# Altium schematic sheet CAN_RPi_CAN.SchDoc
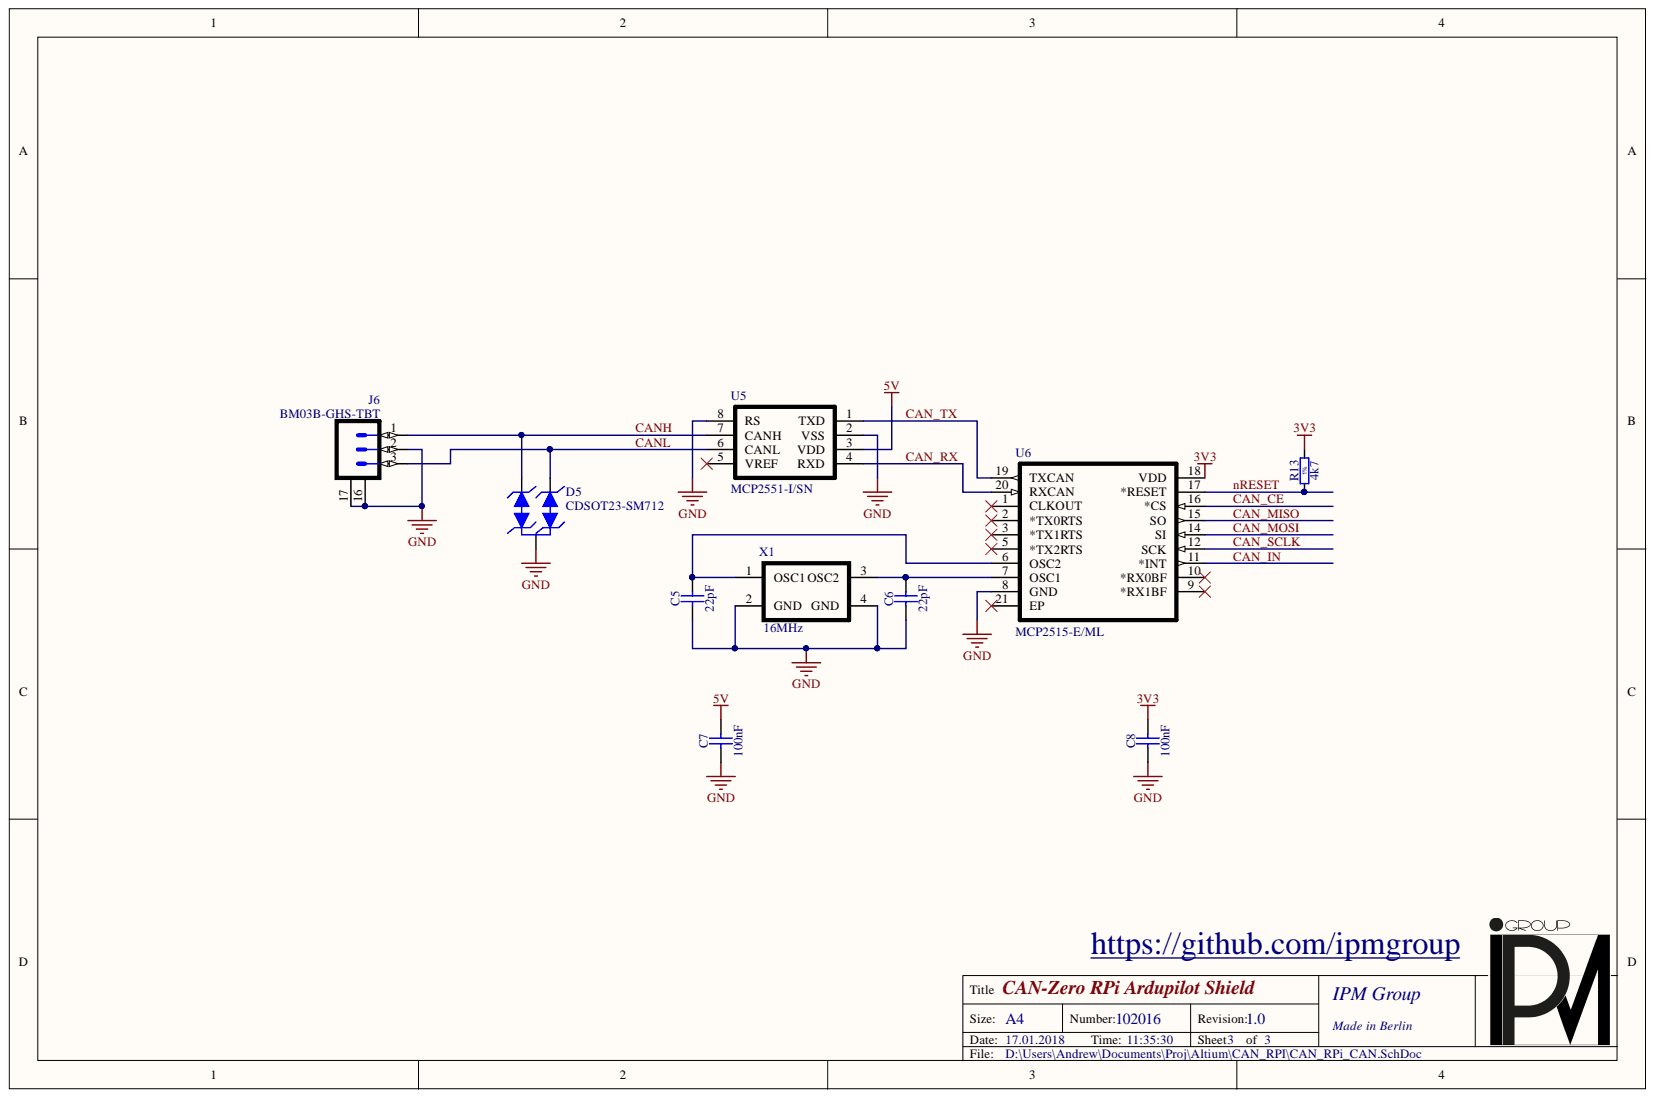
[diagram p0: CAN_RPi_CAN.SchDoc]

## Parts
C5  22pF  [CAPC1005X55N]
C6  22pF  [CAPC1005X55N]
C7  100nF  [CAPC1608X87N]
C8  100nF  [CAPC1005X55N]
D5  CDSOT23-SM712  [SOT23_N]
J6  BM03B-GHS-TBT  [JST_BM03B]
R13  4k7  [RESC1005X40N]
U5  MCP2551-I/SN  [SOIC127P600X175-8N]
U6  MCP2515-E/ML  [QFN50P400X400X100_HS-21N]
X1  value 16MHz  [CRYSTAL-3.2-2.5]  (hidden comment: X1E0000210013 TSX-3225 16 MHZ 9.0PF)

## Nets
3V3: C8.2 R13.2 U6.18(VDD)
5V: C7.2 U5.3(VDD)
GND: C5.1 C6.1 C7.1 C8.1 D5.3 J6.2 J6.16 J6.17 U5.2(VSS) U5.8(RS) U6.8(GND) X1.2(GND) X1.4(GND)
CAN_CE: U6.16(*CS)
CAN_IN: U6.11(*INT)
CAN_MISO: U6.15(SO)
CAN_MOSI: U6.14(SI)
CAN_RX: U5.4(RXD) U6.20(RXCAN)
CAN_SCLK: U6.12(SCK)
CAN_TX: U5.1(TXD) U6.19(TXCAN)
CANH: D5.2 J6.1 U5.7(CANH)
CANL: D5.1 J6.3 U5.6(CANL)
nRESET: R13.1 U6.17(*RESET)
NetC5_2: C5.2 U6.6(OSC2) X1.1(OSC1)
NetC6_2: C6.2 U6.7(OSC1) X1.3(OSC2)

Not connected: U5 5(VREF); U6 1(CLKOUT) 2(*TX0RTS) 3(*TX1RTS) 5(*TX2RTS) 9(*RX1BF) 10(*RX0BF) 21(EP)

## Library data of the parts
C5 C6 = CC0402_22PF_50V_5%_NP0: Non-polarised capacitor; Manufacturer: GENERIC; Manufacturer1 Part Number: 223886915229; Part Description: SMD Multilayer Chip Ceramic Capacitor; Database Table Name: Capacitors SMD; TC: NP0; Tolerance: ±5%; Voltage: 50V; Case: 0402; Component Kind: Standard; Component Type: Standard; Library Name: Capacitors.DbLib; Manufacturer1 ComponentHeight: 0.55mm; Manufacturer1 Example: PHYCOMP; Manufacturer Part Number: CC0402_22PF_50V_5%_NP0; Mounted: Yes; Part Number: CC0402_22PF_50V_5%_NP0; Pin Count: 2; SMD: Yes; Supplier 1: Farnell; Supplier 2: RSComponents; Supplier 3: Digi-Key; Value: 22pF; Author: CERN DEM JLC
C7 = CC0603_100NF_50V_10%_X7R: Non-polarised capacitor; Manufacturer: GENERIC; Manufacturer1 Part Number: 223858615649; Part Description: SMD Multilayer Chip Ceramic Capacitor; Database Table Name: Capacitors SMD; TC: X7R; Tolerance: ±10%; Voltage: 50V; Case: 0603; Component Kind: Standard; Component Type: Standard; Library Name: Capacitors.DbLib; Manufacturer1 ComponentHeight: 0.87mm; Manufacturer1 Example: PHYCOMP; Manufacturer Part Number: CC0603_100NF_50V_10%_X7R; Mounted: Yes; Part Number: CC0603_100NF_50V_10%_X7R; Pin Count: 2; SMD: Yes; Supplier 1: Farnell; Supplier 2: RSComponents; Supplier 3: Digi-Key; Value: 100nF; Author: CERN DEM JLC
C8 = CC0402_100NF_16V_10%_X7R: Non-polarised capacitor; Manufacturer: GENERIC; Manufacturer1 Part Number: GRM155R71C104KA88D; Part Description: SMD Multilayer Chip Ceramic Capacitor; Database Table Name: Capacitors SMD; TC: X7R; Tolerance: ±10%; Voltage: 16V; Case: 0402; Component Kind: Standard; Component Type: Standard; Library Name: Capacitors.DbLib; Manufacturer1 ComponentHeight: 0.55mm; Manufacturer1 Example: MURATA; Manufacturer Part Number: CC0402_100NF_16V_10%_X7R; Mounted: Yes; Part Number: CC0402_100NF_16V_10%_X7R; Pin Count: 2; SMD: Yes; Supplier 1: Farnell; Supplier 2: RSComponents; Supplier 3: Digi-Key; Value: 100nF; Author: CERN DEM JLC
D5 = D Zener2_1: Zener Diode; Manufacturer: BOURNS; Part Description: TVS Diode 7 V or 12 V; Case: SOT-23; Component Kind: Standard; Component Type: Standard; Library Name: My_PCB.SchLib; Manufacturer1 Example: BOURNS; Manufacturer1 Part Number: CDSOT23-SM712; Manufacturer Part Number: CDSOT23-SM712; Mounted: Yes; PackageDescription: Diode, Thru-Hole; 2 Leads; 0.7 in Pin Spacing; Part Number: CDSOT23-SM712; Pin Count: 3; Signal Integrity: D Zener; Simulation: ZENER; SMD: Yes; Supplier 1: Farnell; Supplier 2: RSComponents; Supplier 3: Digi-Key; Supplier Part Number 1: 1824869; Supplier Part Number 2: 767-3728
J6 = JST_GH_BM03: Connector 3 Male; Manufacturer: JST; Part Description: 3 Contacts - Pitch 1.25mm; ComponentHeight: 4.05.mm; Component Kind: Standard; Component Type: Standard; Family: Header 3; Library Name: My_PCB.SchLib; Manufacturer1 Example: JST; Manufacturer1 Part Number: BM03B-GHS-TBT; Manufacturer Part Number: BM03B-GHS-TBT; Mounted: Yes; Part Number: BM03B-GHS-TBT; Pin Count: 3; SMD: Yes; Supplier 1: Farnell; Supplier 2: RSComponents; Supplier 3: Digi-Key; Supplier Part Number 2: 752-1765; Author: CERN DEM JLC
R13 = R0402_4K7_1%_0.0625W_100PPM: Resistor - 1%; Manufacturer: GENERIC; Manufacturer1 Part Number: 232270674702L; Part Description: General Purpose Thick Film Chip Resistor; Database Table Name: Resistors SMD; TC: ±100ppm/°C; Case: 0402; Component Kind: Standard; Component Type: Standard; Library Name: Resistors.DbLib; Manufacturer1 ComponentHeight: 0.4mm; Manufacturer1 Example: YAGEO PHYCOMP; Manufacturer Part Number: R0402_4K7_1%_0.0625W_100PPM; Mounted: Yes; Part Number: R0402_4K7_1%_0.0625W_100PPM; Pin Count: 2; Power: 0.0625W; SMD: Yes; Supplier 1: Farnell; Supplier 2: RSComponents; Supplier 3: Digi-Key; Tolerance: ±1%; Value: 4k7; Author: CERN DEM JLC
U5 = MCP2551-X/SN: High-Speed CAN Transceiver; Manufacturer: MICROCHIP; Part Number: MCP2551-E/SN; Case: SOIC; Component Kind: Standard; Component Type: Standard; Library Name: My_PCB.SchLib; Manufacturer1 Example: MICROCHIP; Manufacturer1 Part Number: MCP2551-I/SN; Manufacturer Part Number: MCP2551-I/SN; Mounted: Yes; Part Description: High-Speed CAN; Pin Count: 8; SMD: Yes; Supplier 1: Farnell; Supplier 2: RSComponents; Supplier 3: Digi-Key; Supplier Part Number 1: 1467746
U6 = MCP2515-X/ML: Stand-Alone CAN Controller with SPI Interface; Manufacturer: MICROCHIP; Case: QFN; Component Kind: Standard; Component Type: Standard; Library Name: My_PCB.SchLib; Manufacturer1 Example: MICROCHIP; Manufacturer1 Part Number: MCP2515-E/ML; Manufacturer Part Number: MCP2515-E/ML; Mounted: Yes; Part Description: Stand-Alone CAN Controller with SPI Interface; Part Number: MCP2515-E/ML; Pin Count: 20; SMD: Yes; Supplier 1: Farnell; Supplier 2: RSComponents; Supplier 3: Digi-Key; Supplier Part Number 1: 2414138
X1 = Quartz: TXC - 7B-16.000MAAJ-T - XTAL, 16.000MHZ, 18PF, SMD, 5.0X3.2; Manufacturer: EPSON; Part Description: CRYSTAL; Case: TSX-3225; Component Kind: Standard; Component Type: Standard; Library Name: My_PCB.SchLib; Manufacturer1 Example: EPSON; Manufacturer1 Part Number: X1E0000210013; Manufacturer Part Number: X1E0000210013 TSX-3225 16 MHZ 9.0PF; Mounted: Yes; Part Number: X1E0000210013 TSX-3225 16 MHZ 9.0PF; Pin Count: 4; SMD: Yes; Supplier 1: Farnell; Supplier 2: RSComponents; Supplier 3: Digi-Key; Supplier Part Number 1: 1712841; Supplier Part Number 2: 904-7528
